# Altium schematic sheet PreHeater.SchDoc
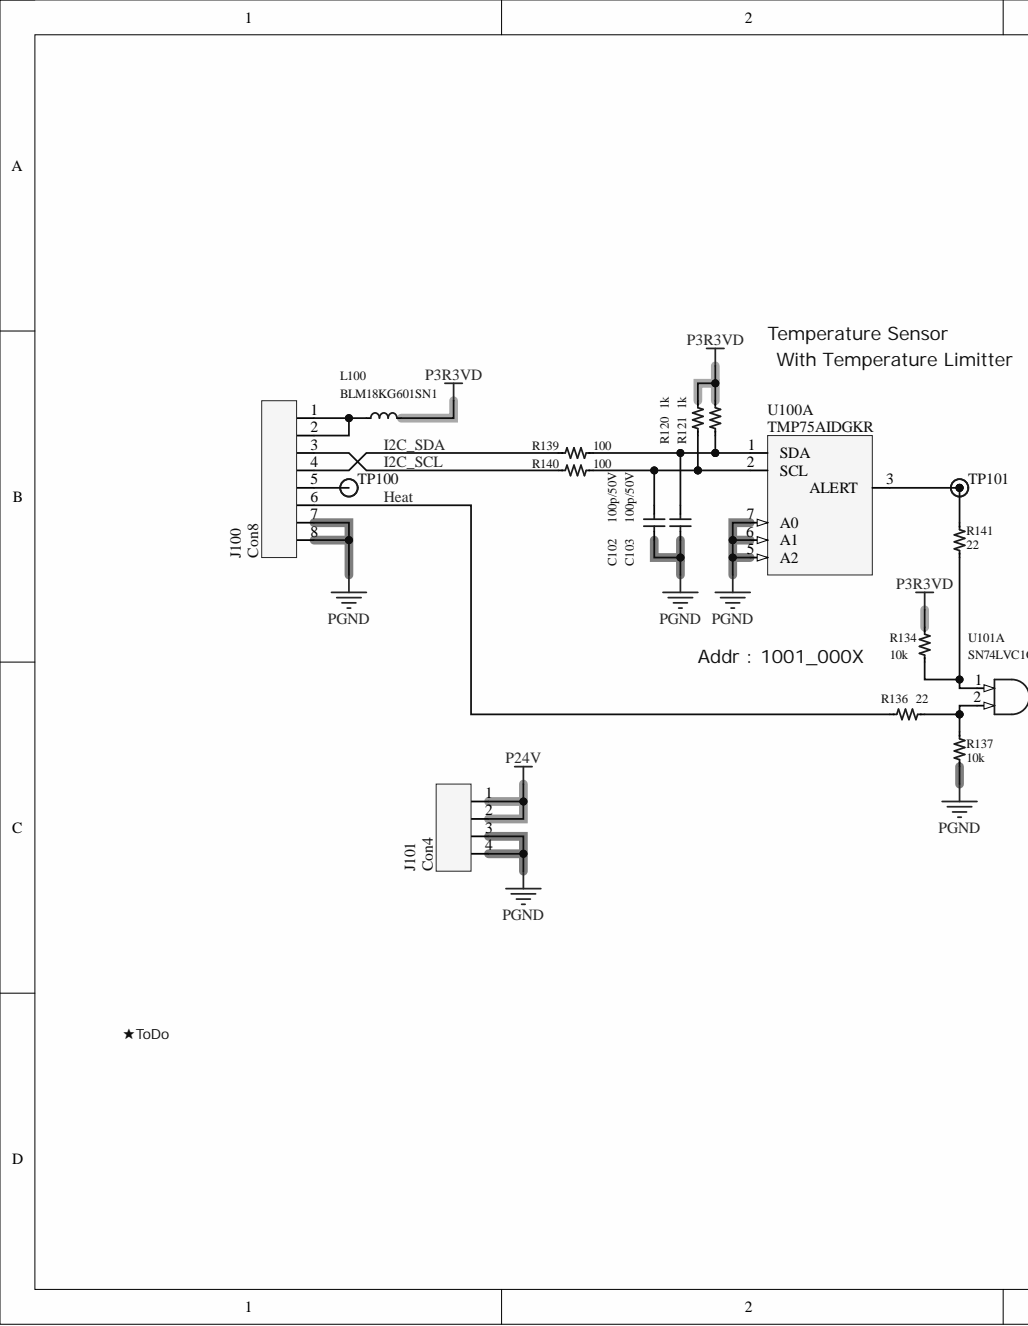
[diagram p0: PreHeater.SchDoc, left]
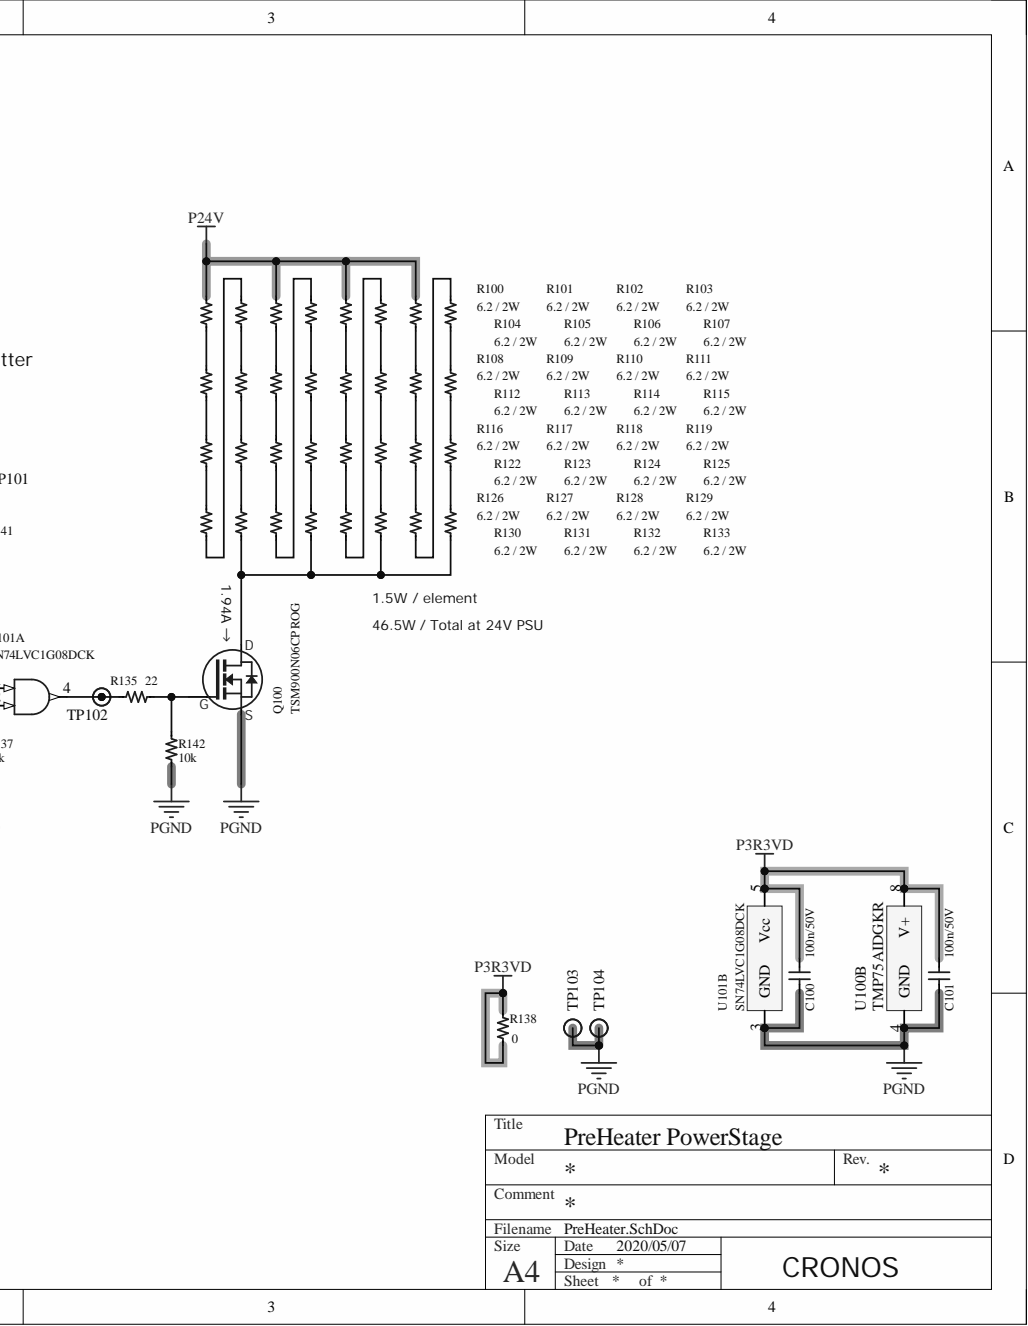
[diagram p1: PreHeater.SchDoc, right]

## Parts
C100  100n/50V  [SC2-1.60X0.80A]
C101  100n/50V  [SC2-1.60X0.80A]
C102  100p/50V  [SC2-1.60X0.80A]
C103  100p/50V  [SC2-1.60X0.80A]
J100  Con8  [SM08B-SRSS-TB]
J101  Con4  [S4B-PH-SM4-TB(LF)(SN)]
L100  BLM18KG601SN1  [SL2-1.60X0.80A]
Q100  TSM900N06CP ROG  [TO252-3_DPAK]
R100  6.2 / 2W  [SR2-6.40x3.20A]  (value not drawn)
R101  6.2 / 2W  [SR2-6.40x3.20A]  (value not drawn)
R102  6.2 / 2W  [SR2-6.40x3.20A]  (value not drawn)
R103  6.2 / 2W  [SR2-6.40x3.20A]  (value not drawn)
R104  6.2 / 2W  [SR2-6.40x3.20A]
R105  6.2 / 2W  [SR2-6.40x3.20A]
R106  6.2 / 2W  [SR2-6.40x3.20A]
R107  6.2 / 2W  [SR2-6.40x3.20A]
R108  6.2 / 2W  [SR2-6.40x3.20A]
R109  6.2 / 2W  [SR2-6.40x3.20A]
R110  6.2 / 2W  [SR2-6.40x3.20A]
R111  6.2 / 2W  [SR2-6.40x3.20A]
R112  6.2 / 2W  [SR2-6.40x3.20A]
R113  6.2 / 2W  [SR2-6.40x3.20A]
R114  6.2 / 2W  [SR2-6.40x3.20A]
R115  6.2 / 2W  [SR2-6.40x3.20A]
R116  6.2 / 2W  [SR2-6.40x3.20A]
R117  6.2 / 2W  [SR2-6.40x3.20A]
R118  6.2 / 2W  [SR2-6.40x3.20A]
R119  6.2 / 2W  [SR2-6.40x3.20A]
R120  1k  [SR2-1.60X0.80A]
R121  1k  [SR2-1.60X0.80A]
R122  6.2 / 2W  [SR2-6.40x3.20A]
R123  6.2 / 2W  [SR2-6.40x3.20A]
R124  6.2 / 2W  [SR2-6.40x3.20A]
R125  6.2 / 2W  [SR2-6.40x3.20A]
R126  6.2 / 2W  [SR2-6.40x3.20A]
R127  6.2 / 2W  [SR2-6.40x3.20A]
R128  6.2 / 2W  [SR2-6.40x3.20A]
R129  6.2 / 2W  [SR2-6.40x3.20A]
R130  6.2 / 2W  [SR2-6.40x3.20A]
R131  6.2 / 2W  [SR2-6.40x3.20A]
R132  6.2 / 2W  [SR2-6.40x3.20A]
R133  6.2 / 2W  [SR2-6.40x3.20A]
R134  10k  [SR2-1.60X0.80A]
R135  22  [SR2-1.60X0.80A]
R136  22  [SR2-1.60X0.80A]
R137  10k  [SR2-1.60X0.80A]
R138  0  [SR2-2.00X1.25A]
R139  100  [SR2-1.60X0.80A]
R140  100  [SR2-1.60X0.80A]
R141  22  [SR2-1.60X0.80A]
R142  10k  [SR2-1.60X0.80A]
TP100  TP  [TP_1.27]
TP101  TP  [TP_1.27]
TP102  TP  [TP_1.27]
TP103  TP  [TP_1.27]
TP104  TP  [TP_1.27]
U100  TMP75AIDGKR  [S-PDSO-G8]  (parts A,B)
U101  SN74LVC1G08DCK  [R-PDSO-G5_]  (parts A,B)

## Nets
P3R3VD: C100.2 C101.2 L100.2 R120.2 R121.2 R134.2 R138.1 R138.2 U100.8(V+) U101.5(Vcc)
P24V: J101.1 J101.2 R100.2 R101.2 R102.2 R103.2
PGND: C100.1 C101.1 C102.1 C103.1 J100.7 J100.8 J101.3 J101.4 Q100.2 R137.1 R142.1 TP103.1 TP104.1 U100.4(GND) U100.5(A2) U100.6(A1) U100.7(A0) U101.3(GND)
Heat: J100.6 R136.2
I2C_SCL: J100.3 R140.2
I2C_SDA: J100.4 R139.2
NetC102_2: C102.2 R120.1 R140.1 U100.2(SCL)
NetC103_2: C103.2 R121.1 R139.1 U100.1(SDA)
NetJ100_1: J100.1 J100.2 L100.1
NetJ100_5: J100.5 TP100.1
NetQ100_1: Q100.1 R135.1 R142.2
NetQ100_3: Q100.3 R130.1 R131.1 R132.1 R133.1
NetR100_1: R100.1 R108.2
NetR101_1: R101.1 R109.2
NetR102_1: R102.1 R110.2
NetR103_1: R103.1 R111.2
NetR104_1: R104.1 R112.2
NetR104_2: R104.2 R126.1
NetR105_1: R105.1 R113.2
NetR105_2: R105.2 R127.1
NetR106_1: R106.1 R114.2
NetR106_2: R106.2 R128.1
NetR107_1: R107.1 R115.2
NetR107_2: R107.2 R129.1
NetR108_1: R108.1 R116.2
NetR109_1: R109.1 R117.2
NetR110_1: R110.1 R118.2
NetR111_1: R111.1 R119.2
NetR112_1: R112.1 R122.2
NetR113_1: R113.1 R123.2
NetR114_1: R114.1 R124.2
NetR115_1: R115.1 R125.2
NetR116_1: R116.1 R126.2
NetR117_1: R117.1 R127.2
NetR118_1: R118.1 R128.2
NetR119_1: R119.1 R129.2
NetR122_1: R122.1 R130.2
NetR123_1: R123.1 R131.2
NetR124_1: R124.1 R132.2
NetR125_1: R125.1 R133.2
NetR134_1: R134.1 R141.2 U101.1
NetR135_2: R135.2 TP102.1 U101.4
NetR136_1: R136.1 R137.2 U101.2
NetR141_1: R141.1 TP101.1 U100.3(ALERT)

## Library data of the parts
C100 C101 = C: Manufacturer: Samsung; Manufacturer Part Number: CL10F104ZB8NNNC; Commodity: MLCC; Replace: OK; Component Kind: Standard; Description: 100n/50V; Footprint(imperial): '0603; Footprint(metric): 1608; Value: 100n/50V; Library Name: I_Lib_cronos_NewLayer.IntLib
C102 C103 = C: 100p/50V, C0G, 1608Size; Manufacturer: Yageo; Manufacturer Part Number: CC0603JRNPO9BN101; Manufacturer_2nd: Murata; Manufacturer_partsnumber_2nd: GRM1885C1H101JA01D; Commodity: MLCC; Replace: OK; Component Kind: Standard; Footprint(imperial): '0603; Footprint(metric): 1608; Value: 100p/50V; Library Name: I_Lib_cronos_NewLayer.IntLib
J100 = Con8: SH, Holiz, 8pin, SMD; Manufacturer: JST; Commodity: Connector; Replace: NG; Component Kind: Standard; Manufacturer Part Number: SM08B-SRSS-TB; Value: Con8; Library Name: I_Lib_cronos_NewLayer.IntLib
J101 = Con4: PH, 4pin, SMT, R/A; Manufacturer: JST; Commodity: Connector; Replace: NG; Component Kind: Standard; Manufacturer Part Number: S4B-PH-SM4-TB(LF)(SN); Value: Con4; Library Name: I_Lib_cronos_NewLayer.IntLib
L100 = L: 600ohm/1.3A/150mohm; Manufacturer: Murata; Commodity: RFC; Replace: OK; Component Kind: Standard; FirstPublished: 2012/10/14; Footprint(imperial): '0603; Footprint(metric): 1608; Manufacturer Part Number: BLM18KG601SN1; Value: 600ohm/1.3A/150mohm; Library Name: I_Lib_cronos_NewLayer.IntLib
Q100 = Nch_MOSFET: Nch, 60V, 11A, 90mohm; Commodity: FET; Component Kind: Standard; Manufacturer Part Number: TSM900N06CP ROG; Mounting: TAIWAN SEMICON; Value: TSM900N06CP ROG; Library Name: I_Lib_cronos_NewLayer.IntLib
R100-R119 R122-R133 = R: 6.2ohm, 2W, 5%, 400ppm/degC; Manufacturer: Ever Ohms; Manufacturer Part Number: CRH2512J6R20E04Z; Commodity: Resistor; Replace: OK; Component Kind: Standard; Footprint(imperial): 2512; Footprint(metric): 6432; Value: 6.2 / 2W; Library Name: I_Lib_cronos_NewLayer.IntLib
R120 R121 = R: 1k, 1%, 1/10W, 1608Size; Manufacturer: Yageo; Manufacturer Part Number: RC0603FR-071KL; Commodity: Resistor; Replace: OK; Component Kind: Standard; Footprint(imperial): 0603; Footprint(metric): 1608; Value: 1k; Library Name: I_Lib_cronos_NewLayer.IntLib
R134 R137 R142 = R: 10k, 1%, 1/10W, 1608Size; Manufacturer: Uniroyal Elec; Manufacturer Part Number: 0603WAF1002T5E; Commodity: Resistor; Replace: OK; Component Kind: Standard; Footprint(imperial): 0603; Footprint(metric): 1608; Value: 10k; Library Name: I_Lib_cronos_NewLayer.IntLib
R135 R136 R141 = R: 22, 1%, 1/10W, 1608Size; Manufacturer: Uniroyal Elec; Manufacturer Part Number: 0603WAF220JT5E; Commodity: Resistor; Replace: OK; Component Kind: Standard; Footprint(imperial): 0603; Footprint(metric): 1608; Value: 22; Library Name: I_Lib_cronos_NewLayer.IntLib
R138 = R: 22, 1%, 1/10W, 2012Size; Manufacturer: WALSIN; Manufacturer Part Number: WR08X000 PTL; Commodity: Resistor; Replace: OK; Component Kind: Standard; Footprint(imperial): 0805; Footprint(metric): 2012; Value: 0; Library Name: I_Lib_cronos_NewLayer.IntLib
R139 R140 = R: 100, 1%, 1/10W, 1608Size; Manufacturer: Yageo; Manufacturer Part Number: RC0603FR-07100RL; Commodity: Resistor; Replace: OK; Component Kind: Standard; Footprint(imperial): 0603; Footprint(metric): 1608; Value: 100; Library Name: I_Lib_cronos_NewLayer.IntLib
TP100-TP104 = TP: Mounting: NM; Component Kind: Standard; Library Name: I_Lib_cronos_NewLayer.IntLib
U100 = TMP75AIDGKR: TempSensor, I2C, -40~125degC, 2.7-5.5V, 9~12bits Res, VSSOP8; Manufacturer: TI; Commodity: Logic; Replace: OK; Component Kind: Standard; Manufacturer Part Number: TMP75AIDGKR; Value: TMP75AIDGKR; Library Name: I_Lib_cronos_NewLayer.IntLib
U101 = SN74LVC1G08DCK: Manufacturer: TI; Component Kind: Standard; Manufacturer Part Number: SN74LVC1G08DCK; Value: SN74LVC1G08DCK; Library Name: I_Lib_cronos_NewLayer.IntLib
